# Altium schematic sheet Microcontroller.SchDoc(Microcontroller)
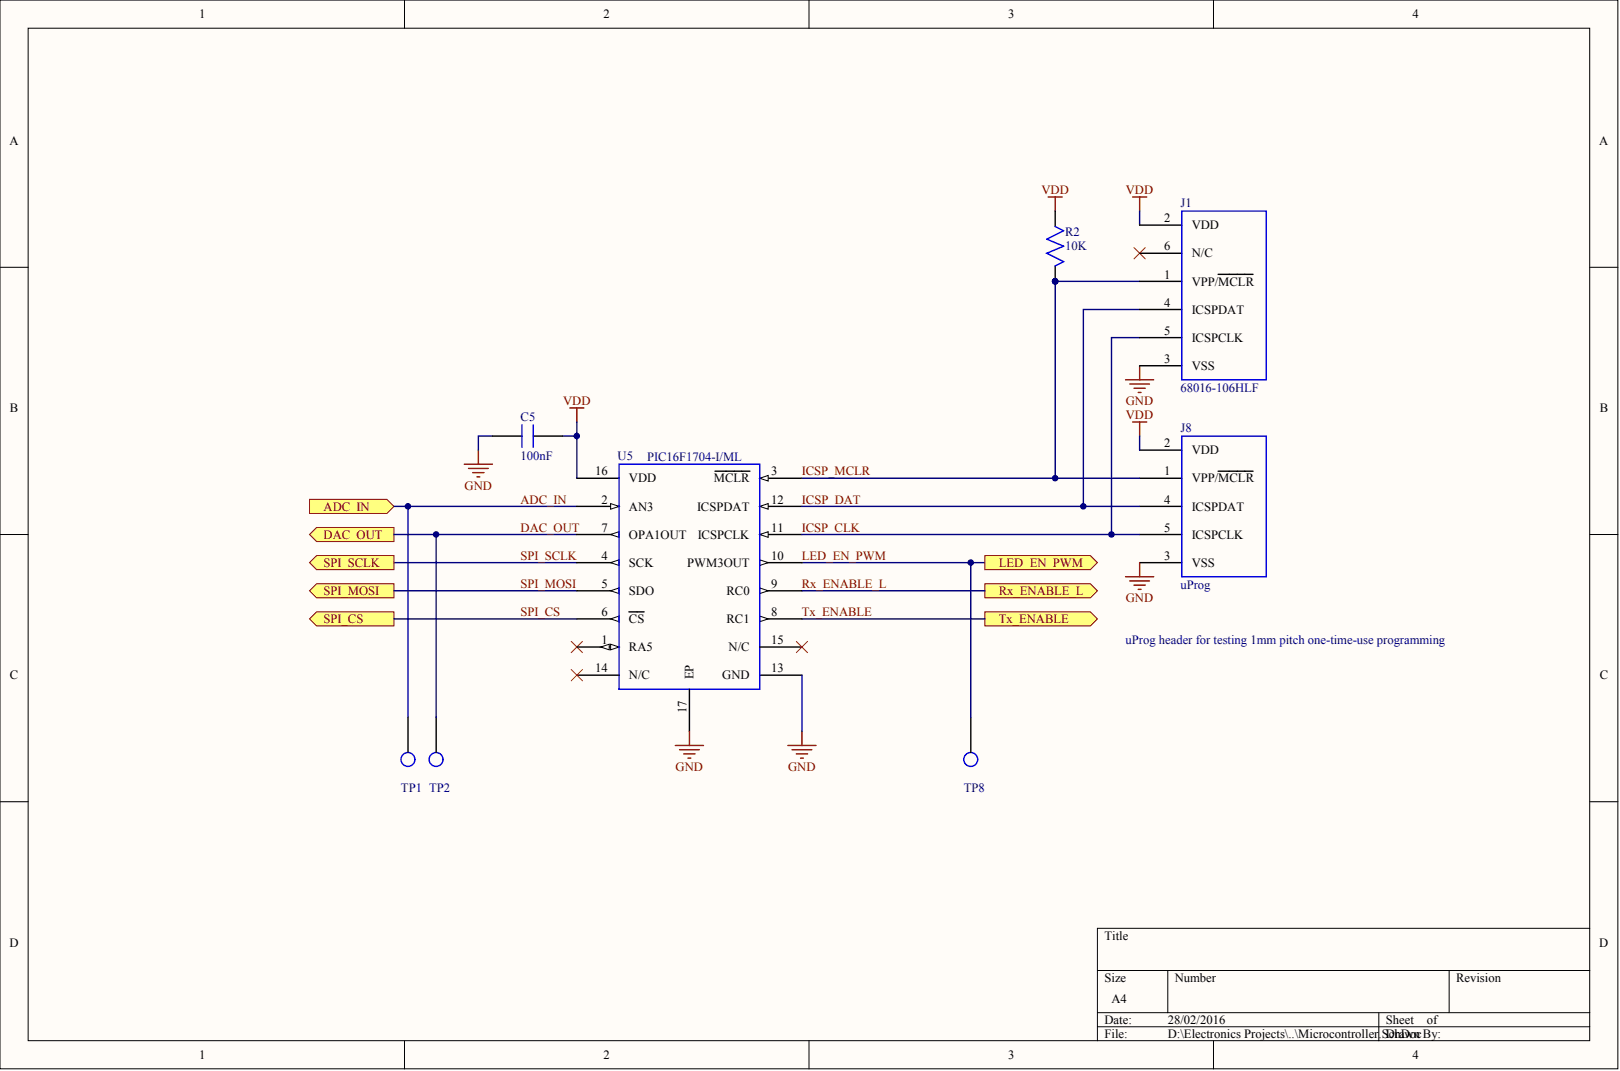
[diagram p0: Microcontroller.SchDoc]

## Parts
C5  Capacitance: 100nF  (hidden comment: CAP_0402_100nF)
J1  Part Number: 68016-106HLF  (hidden comment: CONN_6PIN_HEADER_RIGHTANGLE)
J8  Part Number: uProg  (hidden comment: CONN_uPROG)
R2  Resistance: 10K  (hidden comment: RES_0402_10K)
TP1  TP_5018  (value not drawn)
TP2  TP_5018  (value not drawn)
TP8  TP_5018  (value not drawn)
U5  PIC16F1704-QFN-16  (value not drawn)

## Ports
ADC_IN, DAC_OUT, LED_EN_PWM, RX_ENABLE_L, SPI_CS, SPI_MOSI, SPI_SCLK, TX_ENABLE

## Nets
GND: C5.1 J1.3(VSS) J8.3(VSS) U5.13(GND) U5.17(EP)
VDD: C5.2 J1.2(VDD) J8.2(VDD) R2.2 U5.16(VDD)
ADC_IN: TP1.1 U5.2(AN3) ; port ADC_IN
DAC_OUT: TP2.1 U5.7(OPA1OUT) ; port DAC_OUT
ICSP_CLK: J1.5(ICSPCLK) J8.5(ICSPCLK) U5.11(ICSPCLK)
ICSP_DAT: J1.4(ICSPDAT) J8.4(ICSPDAT) U5.12(ICSPDAT)
ICSP_MCLR: J1.1(VPP/MCLR) J8.1(VPP/MCLR) R2.1 U5.3(MCLR)
LED_EN_PWM: TP8.1 U5.10(PWM3OUT) ; port LED_EN_PWM
RX_ENABLE_L: U5.9(RC0) ; port RX_ENABLE_L
SPI_CS: U5.6(CS) ; port SPI_CS
SPI_MOSI: U5.5(SDO) ; port SPI_MOSI
SPI_SCLK: U5.4(SCK) ; port SPI_SCLK
TX_ENABLE: U5.8(RC1) ; port TX_ENABLE

Not connected: J1 6(N/C); U5 1(RA5) 14(N/C) 15(N/C)

## Library data of the parts
C5 = (no symbol name): Manufacturer: TDK; Part Number: C1005X5R1A104K050BA; Tolerance: 10%; Voltage: 10V
J1 = (no symbol name): Manufacturer: FCI
R2 = (no symbol name): Manufacturer: Vishay Dale; Part Number: CRCW040210K0FKED; Tolerance: 1%
TP1 TP2 TP8 = (no symbol name): Manufacturer: Keystone Electronics; Part Number: 5018
U5 = (no symbol name): Manufacturer: Microchip Technologies; Part Number: PIC16F1704-I/ML; Package: QFN-16-EP
